# Altium schematic sheet Top_Level.SchDoc
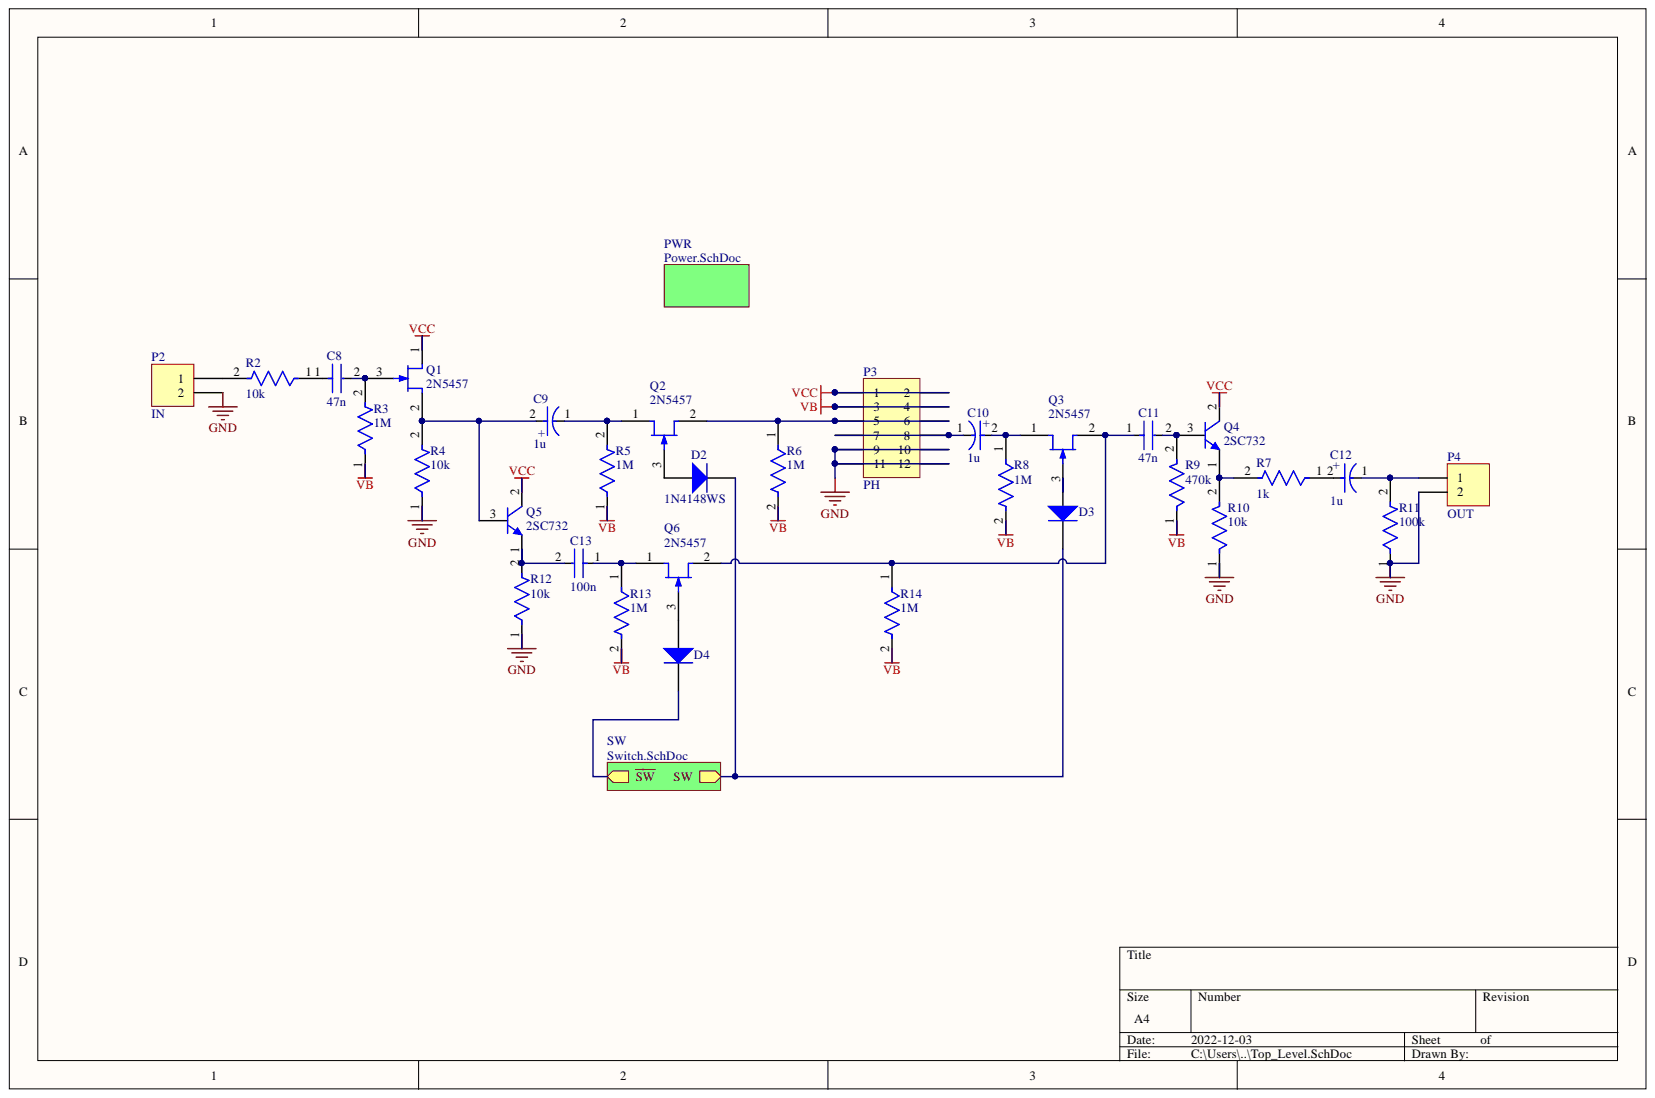
[diagram p0: Top_Level.SchDoc]

## Parts
C8  47n  [FP-CL10-IPC_A]
C9  1u  [FP-TAJA-MFG]
C10  1u  [FP-TAJA-MFG]
C11  47n  [FP-CL10-IPC_A]
C12  1u  [FP-TAJA-MFG]
C13  100n  [FP-CL10-IPC_A]
D2  1N4148WS  [DIOD-SOD323-2-A_V]
D3  1N4148WS  [DIOD-SOD323-2-A_V]
D4  1N4148WS  [DIOD-SOD323-2-A_V]
P2  IN  [HDR1X2]
P3  PH  [HDR2X6]
P4  OUT  [HDR1X2]
Q1  2N5457  [TO-92]
Q2  2N5457  [TO-92]
Q3  2N5457  [TO-92]
Q4  2SC732  [TO-92]
Q5  2SC732  [TO-92]
Q6  2N5457  [TO-92]
R2  10k  [FP-RT0805-MFG]
R3  1M  [FP-RT0805-MFG]
R4  10k  [FP-RT0805-MFG]
R5  1M  [FP-RT0805-MFG]
R6  1M  [FP-RT0805-MFG]
R7  1k  [FP-RT0805-MFG]
R8  1M  [FP-RT0805-MFG]
R9  470k  [FP-RT0805-MFG]
R10  10k  [FP-RT0805-MFG]
R11  100k  [FP-RT0805-MFG]
R12  10k  [FP-RT0805-MFG]
R13  1M  [FP-RT0805-MFG]
R14  1M  [FP-RT0805-MFG]

## Nets
GND: P2.2 P3.9 P3.10 P3.11 P3.12 P4.2 R4.1 R10.1 R11.1 R12.1
VB: P3.3 P3.4 R3.1 R5.1 R6.2 R8.2 R9.1 R13.2 R14.2
VCC: P3.1 P3.2 Q1.1 Q4.2 Q5.2
NetC8_1: C8.1 R2.1
NetC8_2: C8.2 Q1.3 R3.2
NetC9_1: C9.1 Q2.1 R5.2
NetC9_2: C9.2 Q1.2 Q5.3 R4.2
NetC10_1: C10.1 P3.7 P3.8
NetC10_2: C10.2 Q3.1 R8.1
NetC11_1: C11.1 Q3.2 Q6.2 R14.1
NetC11_2: C11.2 Q4.3 R9.2
NetC12_1: C12.1 P4.1 R11.2
NetC12_2: C12.2 R7.1
NetC13_1: C13.1 Q6.1 R13.1
NetC13_2: C13.2 Q5.1 R12.2
NetD2_1: D2.1 Q2.3
NetD2_2: D2.2 D3.2
NetD3_1: D3.1 Q3.3
NetD4_1: D4.1 Q6.3
NetD4_2: D4.2
NetP2_1: P2.1 R2.2
NetP3_5: P3.5 P3.6 Q2.2 R6.1
NetQ4_1: Q4.1 R7.2 R10.2

## Library data of the parts
C8 C11 C13 = CMP-13271-004807-1: Cap Ceramic 1nF 50V C0G ±5% Pad SMD 0603 +125°C T/R; Temperature Coefficient: 300ppm/°C; Capacitance: 1nF; Case/Package: 0603; Case Code (Imperial): 0603; Case Code (Metric): 1608; Component Kind: Standard; Depth: 0.8mm; Dielectric: C0G; Height: 0.8mm; Lead Free: Lead Free; Length: 1.6mm; Library Name: Altium Content Vault; Material: Ceramic; Max Operating Temperature: 125°C; Min Operating Temperature: -55°C; Mount: Surface Mount; Package Quantity: 1; Packaging: Tape and Reel; Radiation Hardening: No; RoHS Compliant: Yes; Series: CL; Thickness: 0.035inch; Tolerance: 5%; Voltage: 50V; Voltage Rating: 50V; Voltage Rating (DC): 50V; Width: 0.031inch
C9 C10 C12 = CMP-1661-00004-2: 10uF 16V  ±10% 3Ω 1206  SMD Tantalum Capacitor; Dissipation Factor: 8%; ESR (Equivalent Series Resistance): 3R; Leakage Current: 1.6uA; Polarity: Polar; Capacitance: 10uF; Case/Package: 1206; Case Code (Imperial): 1206; Case Code (Metric): 3216; Component Kind: Standard; Depth: 1.6mm; Dielectric Material: Tantalum; Features: General Purpose; Height: 1.6mm; Height - Seated (Max): 0.071inch; Lead Free: Lead Free; Lead Pitch: 1.1mm; Length: 3.2mm; Library Name: Altium Content Vault; Max Operating Temperature: 125°C; Min Operating Temperature: -55°C; Mount: Surface Mount; Number of Pins: 2; Package Quantity: 1; Packaging: Tape and Reel; Radiation Hardening: No; REACH SVHC: No SVHC; Termination: SMD/SMT; Tolerance: 10%; Voltage: 16V; Voltage Rating: 16V; Voltage Rating (DC): 16V; Width: 1.6mm
D2-D4 = CMP-1667-00004-1: Fast Switching Diode, 75 V, 0.3 A, -65 to 150 degC, 2-Pin SOD323, RoHS, Tape and Reel; Component Kind: Standard; ComponentLink1Description: Manufacturer URL; ComponentLink1URL: http://www.diodes.com/; ComponentLink2Description: Datasheet; ComponentLink2URL: http://www.diodes.com/datasheets/ds30097.pdf; DatasheetVersion: Rev. 25-2, 03/2016; Library Name: Altium Content Vault; PackageDescription: 2-Pin Small Outline Diode, Body 1.7 x 1.3 mm; PackageReference: SOD323-2
P2 P4 = Header 2: Header, 2-Pin; Component Kind: Standard; LatestRevisionDate: 17-Jul-2002; LatestRevisionNote: Re-released for DXP Platform.; Library Name: Miscellaneous Connectors.IntLib; Signal Integrity: Connector; Publisher: Altium Limited
P3 = Header 6X2: Header, 6-Pin, Dual row; Component Kind: Standard; LatestRevisionDate: 17-Jul-2002; LatestRevisionNote: Re-released for DXP Platform.; Library Name: Miscellaneous Connectors.IntLib; Signal Integrity: Connector; Publisher: Altium Limited
Q1-Q3 Q6 = JFET_NPN: Component Kind: Standard; Library Name: TH_Symbols.SchLib
Q4 Q5 = BJT_NPN: Component Kind: Standard; Library Name: TH_Symbols.SchLib
R2-R14 = CMP-2001-01759-2: RES SMD 10K OHM 0.1% 1/8W 0805; Manufacturer: Yageo; Manufacturer Part Number: RT0805BRE0710KL; Power Rating: 0.125W; Resistance: 10KΩ; Temperature Coefficient: 50 ppm/°C; Tolerance: 0.0025; Value: 10KΩ; Voltage Rating: 150V; Automotive: No; Category: Res; Component Kind: Standard; ComponentLink2Description: Datasheet; ComponentLink2URL: http://www.yageo.com/documents/recent/PYu-RT_1-to-0.01_RoHS_L_9.pdf; Composition: Thin Film; Device Class L1: Passive Components; Device Class L2: Resistors; Device Class L3: Chip SMD Resistors; Digikey Description: RES SMD 10K OHM 0.1% 1/8W 0805; Footprint Url: http://www.yageo.com/exep/pages/download/literatures/PYu-R_Mount_10.pdf; Height: 0.6mm; Ipc Land Pattern Name: RESC200125X50; Lead Free: Yes; Library Name: Altium Content Vault; Mouser Description: Thin Film Resistors 10K ohm 0.1% 1/8W; Octopart: https://octopart.com/rt0805bre0710kl-yageo-1239246; Package: RT0805; RoHS: TRUE; Series: RT; Temperature: -55°C to +155°C; Temperature Range High: +155°C; Temperature Range Low: -55°C
